# Altium schematic sheet Sheet_DFMiniPlayer_v1.SchDoc(Sheet_DFMiniPlayer_v1)
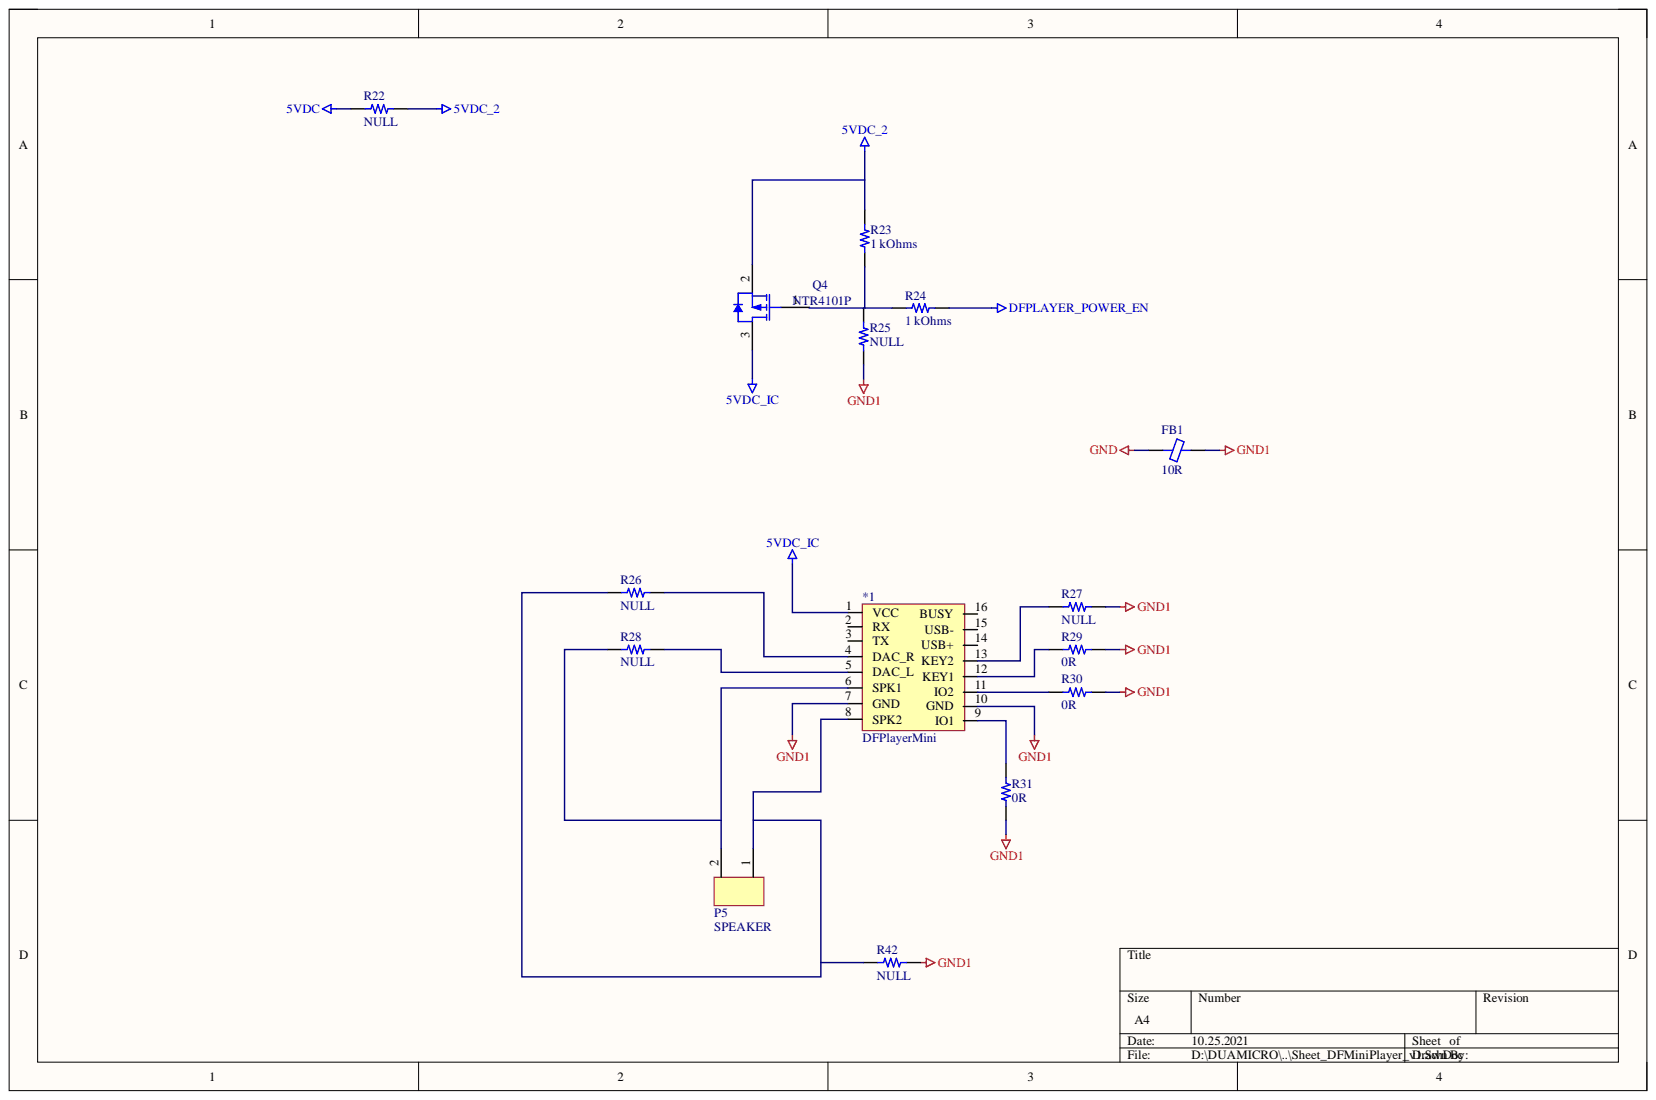
[diagram p0: Sheet_DFMiniPlayer_v1.SchDoc]

## Parts
*1  DFPlayerMini  [DFPlayerMini]
FB1  10R  [RES 0603/1608]
P5  SPEAKER  [KLE2-3.50]
Q4  NTR4101P  [ON SEMI SOT-23-3]
R22  NULL  [RES 0603/1608]
R23  1 kOhms  [RES 0603/1608]
R24  1 kOhms  [RES 0603/1608]
R25  NULL  [RES 0603/1608]
R26  NULL  [RES 0603/1608]
R27  NULL  [RES 0603/1608]
R28  NULL  [RES 0603/1608]
R29  0R  [RES 0603/1608]
R30  0R  [RES 0603/1608]
R31  0R  [RES 0603/1608]
R42  NULL  [RES 0603/1608]

## Nets
5VDC: R22.2
5VDC_2: Q4.2 R22.1 R23.2
5VDC_IC: *1.1(VCC) Q4.3
DFPLAYER_POWER_EN: R24.1
GND: FB1.1
GND1: *1.7(GND) *1.10(GND) FB1.2 R25.1 R27.1 R29.2 R30.2 R31.1 R42.1
Net*1_4: *1.4(DAC_R) R26.1
Net*1_5: *1.5(DAC_L) R28.1
Net*1_6: *1.6(SPK1) P5.2 R28.2
Net*1_8: *1.8(SPK2) P5.1 R26.2 R42.2
Net*1_9: *1.9(IO1) R31.2
Net*1_11: *1.11(IO2) R30.1
Net*1_12: *1.12(KEY1) R29.1
Net*1_13: *1.13(KEY2) R27.2
NetQ4_1: Q4.1 R23.1 R24.2 R25.2

Not connected: *1 2(RX) 3(TX) 14(USB+) 15(USB-) 16(BUSY)

## Library data of the parts
FB1 = FERRITE BEAD_10R_603: 10 Ohms @ 100MHz 1 Signal Line Ferrite Bead 0603 (1608 Metric) 500mA 250mOhm; Manufacturer: Murata Electronics; Manufacturer Part Number: BLM18BA100SN1D; Component Kind: Standard; ComponentLink1Description: DATASHEET; ComponentLink1URL: https://www.murata.com/en-us/products/productdata/8796738650142/ENFA0003.pdf; ComponentLink2Description: Digikey; ComponentLink2URL: https://www.digikey.co.il/product-detail/en/murata-electronics/BLM18BA100SN1D/490-5205-1-ND/1948381; Current Rating (Max): 500mA; DC Resistance (DCR) (Max): 250mOhm; Height (Max): 0.037" (0.95mm); Mounting Type: Surface Mount; Operating Temperature: -55°C ~ 125°C; Package / Case: 0603 (1608 Metric); Part Number: BLM18BA100SN1D; Size / Dimension: 0.063" L x 0.032" W (1.60mm x 0.80mm); Impedance @ Frequency: 10 Ohms @ 100MHz; Library Name: DualMicrolib.SchLib
P5 = con2: KF2EDGR-Y-3.5-2P-1G; Component Kind: Standard; Library Name: SchlibTMS.SchLib
Q4 = MOSFET P-CHANEL: ON SEMICONDUCTOR MOSFET P-CHANNEL 20V 1.8A NTR4101P SOT-23; Part Number: NTR4101PT1G; Drive Voltage: 1.8A,4.5V; Gate Charge: 8.5nC@4.5V; Power Dissipation: 420mW; Vgs(Max): 8V; Vgs(th): 1.2V@250uA; Input Capacitance: 675pF @ 10V; Component Kind: Standard; ComponentLink1Description: Datasheet; ComponentLink1URL: https://www.onsemi.com/pub/Collateral/NTR4101P-D.PDF; ComponentLink2Description: Digi Key; ComponentLink2URL: www.digikey.be/product-detail/en/on-semiconductor/NTR4101PT1G/NTR4101PT1GOSCT-ND/687132; Current - Continuous Drain: 1.8A; Drain to Source Voltage: 20V; FET Type: P- Chanel; Manufacturer: ON; Operating Temperature: -55´C`~150´C; Supplier: Digi Key; Supplier Device Package: SOT-23-3; Technology: MOSFET; Library Name: ESKA_INT_ALL.IntLib
R22 R25-R28 R42 = Resistor_NULL_0603: RES SMD NULL OHM JUMPER 1/10W 0603; Manufacturer: Panasonic Electronic Components; PowerRating: 0.1W, 1/10W; TemperatureRange: -55C ~ 155C; Component Kind: Standard; ComponentLink1Description: Datasheet; ComponentLink1URL: https://industrial.panasonic.com/ww/products/resistors/chip-resistors/general-purpose-chip-resistors/thick-film-chip-resistors/ERJ3GEY0R00V; ComponentLink2Description: Digi-Key Listing; ComponentLink2URL: https://www.digikey.com/product-detail/en/panasonic-electronic-components/ERJ-3GEY0R00V/P0.0GCT-ND/134711; Device Package: 0603 (1608 Metric); Price: $0.10000/min1; TemperatureCoefficient: -; Tolerance: Jumper; Value: NULL; Library Name: DualMicrolib.SchLib
R23 R24 = Resistor_1K_0603_5%: RES SMD 1K OHM 5% 1/16W 0603; Manufacturer: Stackpole Electronics; Part Number: RMCF0603JG1K00; PowerRating: 0.1W, 1/10W; TemperatureCoefficient: ±100ppm/°C; TemperatureRange: -55°C ~ 125°C; Component Kind: Standard; ComponentLink1Description: Datasheet; ComponentLink1URL: http://www.seielect.com/catalog/sei-packaging.pdf; ComponentLink2Description: Digi-Key Listing; ComponentLink2URL: https://www.digikey.com/product-detail/en/stackpole-electronics-inc/RMCF0603FT100K/RMCF0603FT100KCT-ND/1943118; Device Package: 0603 (1608 Metric); Price: $0.1000/min1; Supplier 1: Digi-Key; Supplier Part Number 1: RMCF0603JG1K00; Tolerance: ±5%; Value: 1 kOhms; Library Name: DualMicrolib.SchLib
R29-R31 = Resistor_0R_0603: RES SMD 0 OHM JUMPER 1/10W 0603; Manufacturer: Panasonic Electronic Components; Part Number: ERJ-3GEY0R00V; PowerRating: 0.1W, 1/10W; TemperatureRange: -55C ~ 155C; Component Kind: Standard; ComponentLink1Description: Datasheet; ComponentLink1URL: https://industrial.panasonic.com/ww/products/resistors/chip-resistors/general-purpose-chip-resistors/thick-film-chip-resistors/ERJ3GEY0R00V; ComponentLink2Description: Digi-Key Listing; ComponentLink2URL: https://www.digikey.com/product-detail/en/panasonic-electronic-components/ERJ-3GEY0R00V/P0.0GCT-ND/134711; Device Package: 0603 (1608 Metric); Price: $0.10000/min1; Supplier 1: Digi-Key; Supplier Part Number 1: P0.0GCT-ND; TemperatureCoefficient: -; Tolerance: Jumper; Value: 0R; Library Name: DualMicrolib.SchLib
*1 = DFPlayerMini: Component Kind: Standard; Library Name: Altium_Sch.SchLib
